# Altium schematic sheet 1.1.4_Interface_board_USB.SchDoc
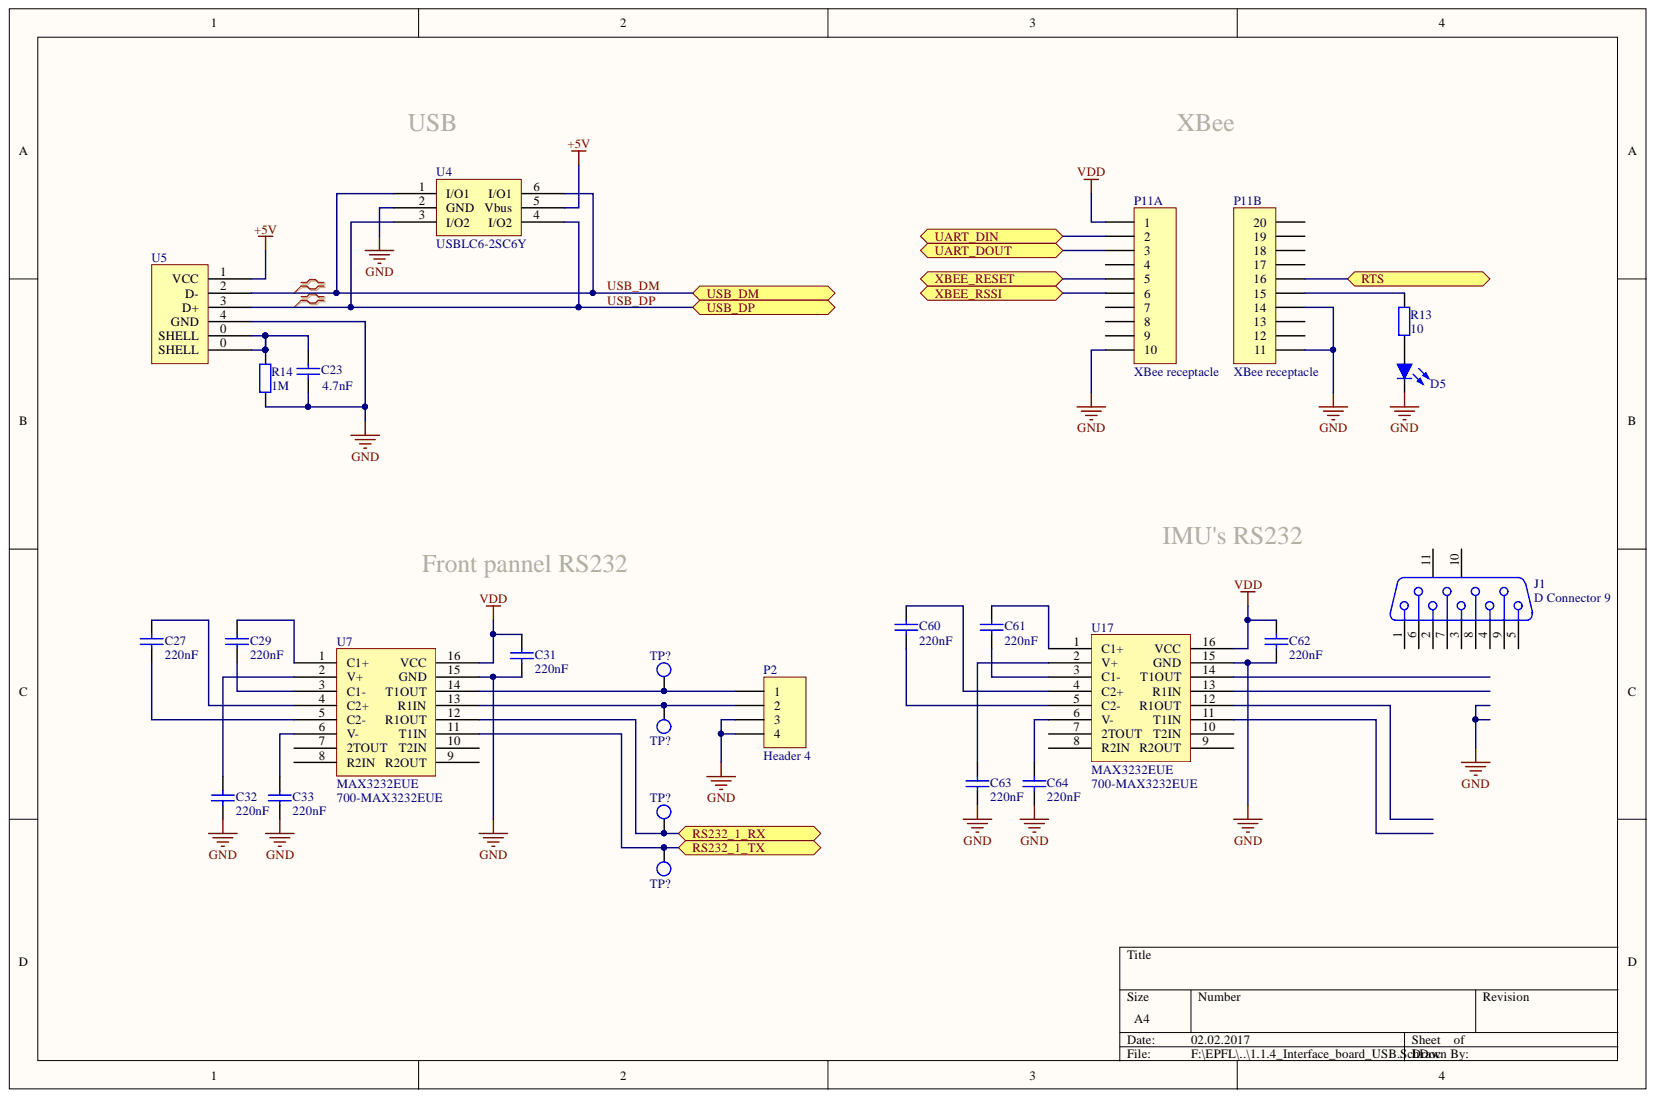
[diagram p0: 1.1.4_Interface_board_USB.SchDoc]

## Parts
C23  4.7nF  [CAP0603R]
C27  220nF  [CAP0603R]
C29  220nF  [CAP0603R]
C31  220nF  [CAP0603R]
C32  220nF  [CAP0603R]
C33  220nF  [CAP0603R]
C60  220nF  [CAP0603R]
C61  220nF  [CAP0603R]
C62  220nF  [CAP0603R]
C63  220nF  [CAP0603R]
C64  220nF  [CAP0603R]
D5  LED  [WL-SMCW]  (value not drawn)
J1  D Connector 9  [DSUB1.385-2H9]
P2  Header 4  [HDR1X4]
P11  XBee receptacle  [XBee receptacle]  (parts A,B)
R13  10  [0603]
R14  1M  [0603]
TP?  Test point  [TP]  (value not drawn)
TP?  Test point  [TP]  (value not drawn)
TP?  Test point  [TP]  (value not drawn)
TP?  Test point  [TP]  (value not drawn)
U4  USBLC6-2SC6Y  [SOT23-6L]
U5  USB_type_B_KUSBXHT-BS1N-O-HRF  [USB type B Right angle]  (value not drawn)
U7  MAX3232EUE  Supplier Part Number 1: 700-MAX3232EUE  [TSSOP 16]
U17  MAX3232EUE  Supplier Part Number 1: 700-MAX3232EUE  [TSSOP 16]

## Ports
RS232_1_RX, RS232_1_TX, RTS, UART_DIN, UART_DOUT, USB_DM, USB_DP, XBEE_RESET, XBEE_RSSI

## Nets
+5V: U4.5(Vbus) U5.1(VCC)
GND: C23.1 C31.1 C32.1 C33.1 C62.1 C63.1 C64.1 D5.C P2.3 P2.4 P11.10 P11.11 P11.14 R14.1 U4.2(GND) U5.4(GND) U7.15(GND) U17.15(GND)
VDD: C31.2 C62.2 P11.1 U7.16(VCC) U17.16(VCC)
RS232_1_RX: TP?.1 U7.11(T1IN) U7.12(R1OUT) ; port RS232_1_RX, port RS232_1_TX
RTS: P11.16 ; port RTS
UART_DIN: P11.2 ; port UART_DIN
UART_DOUT: P11.3 ; port UART_DOUT
USB_DM: U4.1(I/O1) U4.6(I/O1) U5.2(D-) ; port USB_DM
USB_DP: U4.3(I/O2) U4.4(I/O2) U5.3(D+) ; port USB_DP
XBEE_RESET: P11.5 ; port XBEE_RESET
XBEE_RSSI: P11.6 ; port XBEE_RSSI
NetC23_2: C23.2 R14.2 U5.0(SHELL)
NetC27_1: C27.1 U7.5(C2-)
NetC27_2: C27.2 U7.4(C2+)
NetC29_1: C29.1 U7.3(C1-)
NetC29_2: C29.2 U7.1(C1+)
NetC32_2: C32.2 U7.2(V+)
NetC33_2: C33.2 U7.6(V-)
NetC60_1: C60.1 U17.5(C2-)
NetC60_2: C60.2 U17.4(C2+)
NetC61_1: C61.1 U17.3(C1-)
NetC61_2: C61.2 U17.1(C1+)
NetC63_2: C63.2 U17.2(V+)
NetC64_2: C64.2 U17.6(V-)
NetD5_A: D5.A R13.1
NetP2_1: P2.1 TP?.1 U7.14(T1OUT)
NetP2_2: P2.2 TP?.1 U7.13(R1IN)
NetP11_15: P11.15 R13.2

Not connected: J1 1 2 3 4 5 6 7 8 9 10 11; P11 4 7 8 9 12 13 17 18 19 20; U7 7(2TOUT) 8(R2IN) 9(R2OUT) 10(T2IN); U17 7(2TOUT) 8(R2IN) 9(R2OUT) 10(T2IN) 11(T1IN) 12(R1OUT) 13(R1IN) 14(T1OUT)

## Library data of the parts
C23 = C_4.7nF: Capacitor; Component Kind: Standard; Signal Integrity: Cap; Simulation: CAP; Supplier 1: Mouser; Supplier Part Number 1: 81-GCG188R92A472KA1D; Library Name: Rocket_library.SchLib
C27 C29 C31-C33 C60-C64 = C_220nF: Capacitor; Component Kind: Standard; Signal Integrity: Cap; Simulation: CAP; Supplier 1: Mouser; Supplier Part Number 1: 81-GCG188R91H224KA1D; Library Name: Rocket_library.SchLib
D5 = LED_SMD_GREEN_0603: Standard LEDs - SMD WL-SMCW Waterclr 0603 Green; Component Kind: Standard; Supplier 1: Mouser; Supplier Part Number 1: 710-150060GS75000; Library Name: Rocket_library.SchLib
J1 = D Connector 9: Receptacle Assembly, 9 Position, Right Angle; PackageDocument: O; Positions: 9; Component Kind: Standard; DatasheetDocument: 1-Sep-1999; Gender: Receptacle; LatestRevisionDate: 17-Jul-2002; LatestRevisionNote: Re-released for DXP Platform.; Library Name: Miscellaneous Connectors.IntLib; Orientation: Right Angle; PackageReference: 788750; Published: 15-Jun-2001; Signal Integrity: Connector; Publisher: Altium Limited
P2 = Header 4: Header, 4-Pin; Component Kind: Standard; LatestRevisionDate: 17-Jul-2002; LatestRevisionNote: Re-released for DXP Platform.; Library Name: Miscellaneous Connectors.IntLib; Signal Integrity: Connector; Publisher: Altium Limited
R13 = R_0603_025W_10Ohm: 0.25W 10Ohm; Component Kind: Standard; Supplier 1: Mouser; Supplier Part Number 1: 667-ERJ-PA3J100V; Library Name: Rocket_library.SchLib
R14 = R_0603_01W_1M: 0.1W 1M; Component Kind: Standard; Signal Integrity: Res; Simulation: RESISTOR; Supplier 1: Mouser; Supplier Part Number 1: 667-ERJ-3GEYJ105V; Library Name: Rocket_library.SchLib
U4 = USBLC6-2SC6Y: ESD Suppressors; Component Kind: Standard; Supplier 1: Mouser; Supplier Part Number 1: 511-USBLC6-2SC6Y; Library Name: Rocket_library.SchLib
U5 = USB_type_B_KUSBXHT-BS1N-O-HRF: USB type B; Component Kind: Standard; Supplier 1: Mouser; Supplier Part Number 1: 806-KUSBXHT-BS1NOHRF; Library Name: Rocket_library.SchLib
U7 U17 = MAX3232EUE: RS-232 Interface IC 3-5.5V 235kbps Transceiver; Component Kind: Standard; Supplier 1: Mouser; Library Name: Rocket_library.SchLib
P11 = XBee receptacle: Component Kind: Standard; Description: XBee receptacle; Supplier 1: Mouser; Supplier Part Number 1: 855-M22-7131042; Library Name: Rocket_library.SchLib
TP? TP? TP? TP? = Test point: Component Kind: Standard; Library Name: Rocket_library.SchLib
